# Altium schematic sheet DWM1000Breakout.SchDoc(DWM1000Breakout)
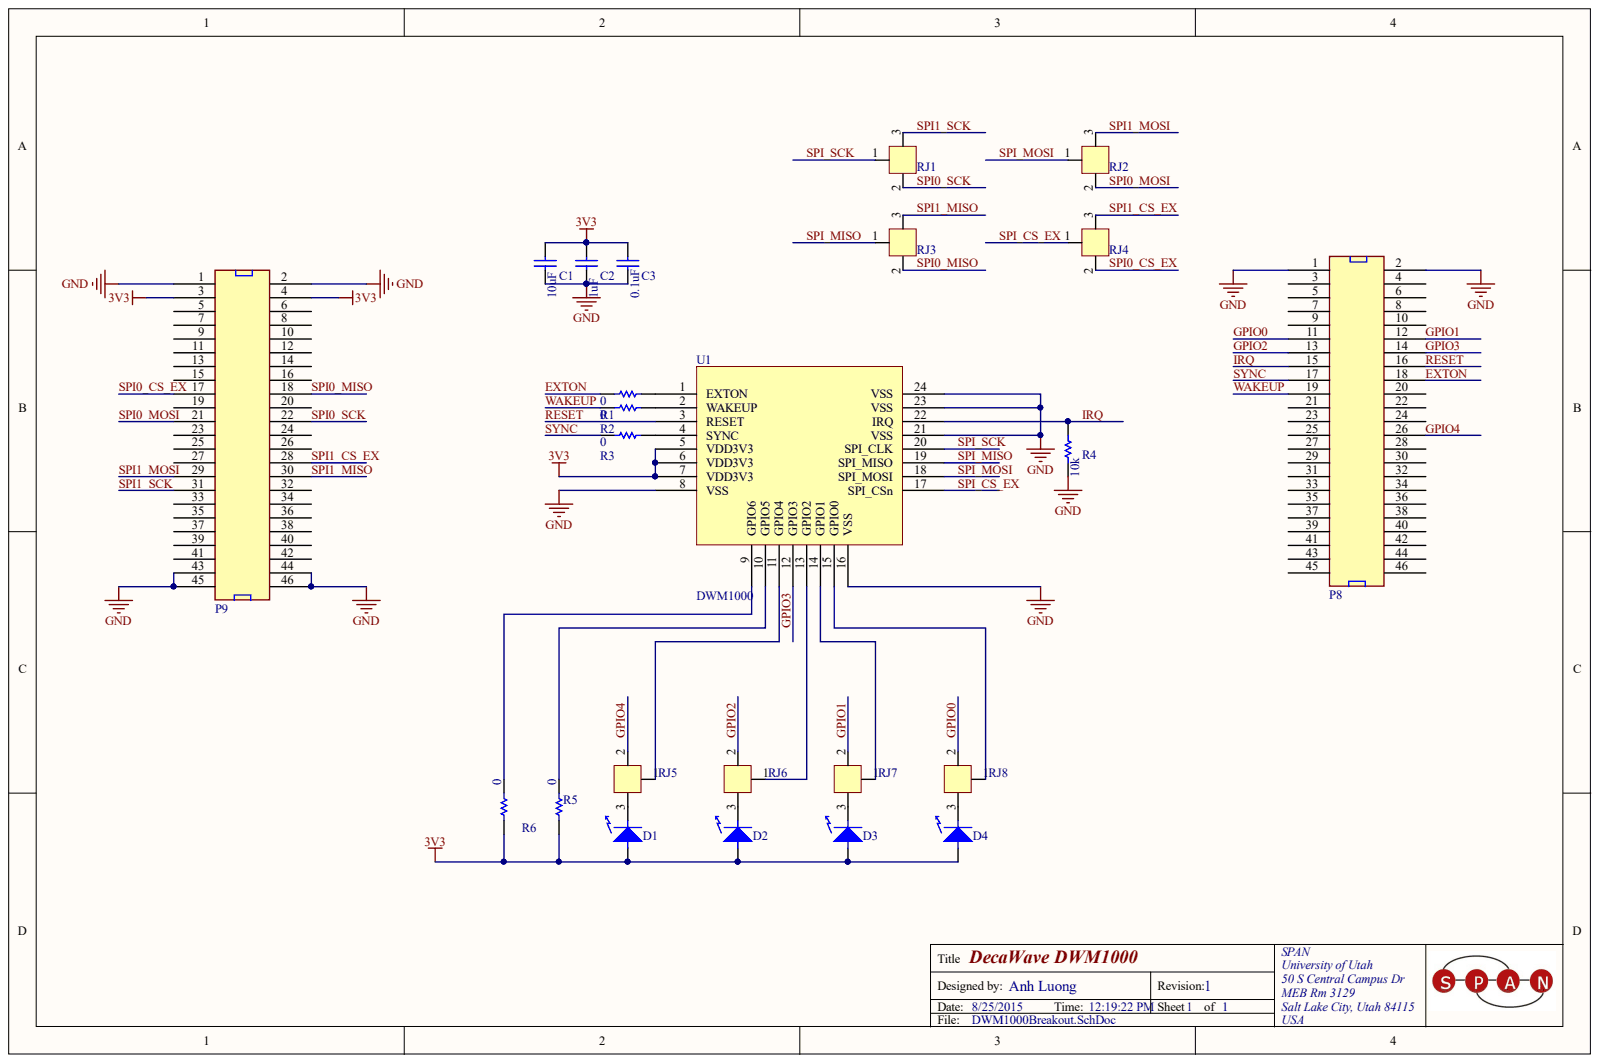
[diagram p0: DWM1000Breakout.SchDoc]

## Parts
C1  GRM188R60J106ME47D  [CAPC1608X08N (0603)]  (value not drawn)
C2  GRM188R61A105KA61D  [CAPC1608X08N (0603)]  (value not drawn)
C3  GRM188R71C104KA01D  [CAPC1608X08N (0603)]  (value not drawn)
D1  LG Q971-KN-1  [CHIPLED0603]  (value not drawn)
D2  LG Q971-KN-1  [CHIPLED0603]  (value not drawn)
D3  LG Q971-KN-1  [CHIPLED0603]  (value not drawn)
D4  LG Q971-KN-1  [CHIPLED0603]  (value not drawn)
P8  PREC023DAAN-RC  [HEADER23X2]  (value not drawn)
P9  PREC023DAAN-RC  [HEADER23X2]  (value not drawn)
R1  MCR03ERTJ000  [RESC1608X06N (0603)]  (value not drawn)
R2  MCR03ERTJ000  [RESC1608X06N (0603)]  (value not drawn)
R3  MCR03ERTJ000  [RESC1608X06N (0603)]  (value not drawn)
R4  Resistance (Ohms): 10k  [RESC1608X06N (0603)]  (hidden comment: MCR03ERTJ103)
R5  MCR03ERTJ000  [RESC1608X06N (0603)]  (value not drawn)
R6  MCR03ERTJ000  [RESC1608X06N (0603)]  (value not drawn)
RJ1  R_J  [RJ]
RJ2  R_J  [RJ]
RJ3  R_J  [RJ]
RJ4  R_J  [RJ]
RJ5  R_J  [RJ]
RJ6  R_J  [RJ]
RJ7  R_J  [RJ]
RJ8  R_J  [RJ]
U1  DWM1000  [DWM1000]

## Nets
3V3: C1.2 C2.2 C3.2 D1.1 D2.1 D3.1 D4.1 P9.3 P9.4 R5.2 R6.2 U1.5(VDD3V3) U1.6(VDD3V3) U1.7(VDD3V3)
GND: C1.1 C2.1 C3.1 P8.1 P8.2 P9.1 P9.2 P9.43 P9.44 P9.45 P9.46 R4.1 U1.8(VSS) U1.16(VSS) U1.21(VSS) U1.23(VSS) U1.24(VSS)
EXTON: P8.18 R1.1
GPIO0: P8.11 RJ8.2
GPIO1: P8.12 RJ7.2
GPIO2: P8.13 RJ6.2
GPIO3: P8.14 U1.12(GPIO3)
GPIO4: P8.26 RJ5.2
IRQ: P8.15 R4.2 U1.22(IRQ)
RESET: P8.16 U1.3(RESET)
SPI0_CS_EX: P9.17 RJ4.2
SPI0_MISO: P9.18 RJ3.2
SPI0_MOSI: P9.21 RJ2.2
SPI0_SCK: P9.22 RJ1.2
SPI1_CS_EX: P9.28 RJ4.3
SPI1_MISO: P9.30 RJ3.3
SPI1_MOSI: P9.29 RJ2.3
SPI1_SCK: P9.31 RJ1.3
SPI_CS_EX: RJ4.1 U1.17(SPI_CSn)
SPI_MISO: RJ3.1 U1.19(SPI_MISO)
SPI_MOSI: RJ2.1 U1.18(SPI_MOSI)
SPI_SCK: RJ1.1 U1.20(SPI_CLK)
SYNC: P8.17 R3.1
WAKEUP: P8.19 R2.1
NetD1_2: D1.2 RJ5.3
NetD2_2: D2.2 RJ6.3
NetD3_2: D3.2 RJ7.3
NetD4_2: D4.2 RJ8.3
NetR1_2: R1.2 U1.1(EXTON)
NetR2_2: R2.2 U1.2(WAKEUP)
NetR3_2: R3.2 U1.4(SYNC)
NetR5_1: R5.1 U1.10(GPIO5)
NetR6_1: R6.1 U1.9(GPIO6)
NetRJ5_1: RJ5.1 U1.11(GPIO4)
NetRJ6_1: RJ6.1 U1.13(GPIO2)
NetRJ7_1: RJ7.1 U1.14(GPIO1)
NetRJ8_1: RJ8.1 U1.15(GPIO0)

Not connected: P8 3 4 5 6 7 8 9 10 20 21 22 23 24 25 27 28 29 30 31 32 33 34 35 36 37 38 39 40 41 42 43 44 45 46; P9 5 6 7 8 9 10 11 12 13 14 15 16 19 20 23 24 25 26 27 32 33 34 35 36 37 38 39 40 41 42

## Library data of the parts
C1 = GRM188R60J106ME47D: CAP CER 10UF 6.3V 20% X5R 0603; Manufacturer: Murata Electronics North America; Capacitance: 10µF; Applications: General Purpose; Category: Capacitors; Component Kind: Standard; ComponentLink1Description: http://search.murata.co.jp/Ceramy/image/img/A01X/G101/ENG/GRM188R60J106ME47-01.pdf; ComponentLink1URL: http://search.murata.co.jp/Ceramy/image/img/A01X/G101/ENG/GRM188R60J106ME47-01.pdf; Features: -; Height - Seated (Max): -; Lead Spacing: -; Lead Style: -; Manufacturer Part Number: GRM188R60J106ME47D; Mounting Type: Surface Mount, MLCC; Operating Temperature: -55°C ~ 85°C; Package / Case: 0603 (1608 Metric); Packaging: Digi-Reel®; Pricing 1: 1=0.24, 10=0.2, 50=0.1126, 100=0.1, 250=0.075, 500=0.06626, 1000=0.05875 (USD); Ratings: -; RoHS: RoHS Compliant; Size / Dimension: 0.063" L x 0.031" W (1.60mm x 0.80mm); Stock 1: 2128324; Supplier 1: Digi-Key; Supplier 2: Digi-Key; Temperature Coefficient: X5R; Thickness (Max): 0.035" (0.90mm); Tolerance: ±20%; Voltage - Rated: 6.3V; Library Name: DWM1000Breakout.SchLib
C2 = GRM188R61A105KA61D: CAP CER 1UF 10V 10% X5R 0603; Manufacturer: Murata Electronics North America; Capacitance: 1.0µF; Applications: General Purpose; Category: Capacitors; Component Kind: Standard; ComponentLink1Description: http://search.murata.co.jp/Ceramy/image/img/A01X/G101/ENG/GRM188R61A105KA61-01.pdf; ComponentLink1URL: http://search.murata.co.jp/Ceramy/image/img/A01X/G101/ENG/GRM188R61A105KA61-01.pdf; Features: -; Height - Seated (Max): -; Lead Spacing: -; Lead Style: -; Manufacturer Part Number: GRM188R61A105KA61D; Mounting Type: Surface Mount, MLCC; Operating Temperature: -55°C ~ 85°C; Package / Case: 0603 (1608 Metric); Packaging: Cut Tape (CT); Pricing 1: 1=0.1, 10=0.041, 50=0.0224, 100=0.019, 250=0.01552, 500=0.01328, 1000=0.01035 (USD); Ratings: -; RoHS: RoHS Compliant; Size / Dimension: 0.063" L x 0.031" W (1.60mm x 0.80mm); Stock 1: 244919; Supplier 1: Digi-Key; Temperature Coefficient: X5R; Thickness (Max): 0.035" (0.90mm); Tolerance: ±10%; Voltage - Rated: 10V; Library Name: DWM1000Breakout.SchLib
C3 = GRM188R71C104KA01D: CAP CER 0.1UF 16V 10% X7R 0603; Manufacturer: Murata Electronics North America; Capacitance: 0.1µF; Applications: General Purpose; Category: Capacitors; Component Kind: Standard; ComponentLink1Description: http://search.murata.co.jp/Ceramy/image/img/A01X/G101/ENG/GRM188R71C104KA01-01.pdf; ComponentLink1URL: http://search.murata.co.jp/Ceramy/image/img/A01X/G101/ENG/GRM188R71C104KA01-01.pdf; Features: -; Height - Seated (Max): -; Lead Spacing: -; Lead Style: -; Manufacturer Part Number: GRM188R71C104KA01D; Mounting Type: Surface Mount, MLCC; Operating Temperature: -55°C ~ 125°C; Package / Case: 0603 (1608 Metric); Packaging: Cut Tape (CT); Pricing 1: 1=0.1, 10=0.019, 50=0.0102, 100=0.0086, 250=0.00704, 500=0.006, 1000=0.00468 (USD); Ratings: -; RoHS: RoHS Compliant; Size / Dimension: 0.063" L x 0.031" W (1.60mm x 0.80mm); Stock 1: 1458181; Supplier 1: Digi-Key; Temperature Coefficient: X7R; Thickness (Max): 0.035" (0.90mm); Tolerance: ±10%; Voltage - Rated: 16V; Library Name: DWM1000Breakout.SchLib
D1-D4 = LG Q971-KN-1: LED CHIPLED 570NM GREEN 0603 SMD; Manufacturer: OSRAM Opto Semiconductors Inc; Current - Test: 20mA; Lens Style/Size: Rectangle with Flat Top, 1mm x 0.8mm; Lens Type: Diffused; Millicandela Rating: 10mcd; Viewing Angle: 160°; Voltage - Forward (Vf) Typ: 2.2V; Wavelength - Peak: 572nm; Category: Optoelectronics; Color: Green; Component Kind: Standard; ComponentLink1Description: http://catalog.osram-os.com/catalogue/catalogue.do;jsessionid=DE4D071D0D2758CC117C711BF848053E?act=downloadFile&favOid=0200000600005069000100b6; ComponentLink1URL: http://catalog.osram-os.com/catalogue/catalogue.do;jsessionid=DE4D071D0D2758CC117C711BF848053E?act=downloadFile&favOid=0200000600005069000100b6; Height: 0.80mm; Luminous Flux @ Current - Test: -; Manufacturer Part Number: LG Q971-KN-1; Mounting Type: Surface Mount; Package / Case: 0603 (1608 Metric); Packaging: Tape & Reel (TR); Pricing 1: 4000=0.03632 (USD); RoHS: RoHS Compliant; Size / Dimension: 1.60mm L x 0.80mm W; Stock 1: 44000; Supplier 1: Digi-Key; Wavelength - Dominant: 570nm; Library Name: DWM1000Breakout.SchLib
P8 P9 = PREC023DAAN-RC: CONN HEADER .100" DUAL STR 46POS; Manufacturer: Sullins Connector Solutions; Connector Type: Header, Unshrouded; Number of Positions: 46; Number of Positions Loaded: All; Pitch: 0.100" (2.54mm); Category: Connectors, Interconnects; Color: Black; Component Kind: Standard; ComponentLink1Description: http://media.digikey.com/PDF/Data%20Sheets/Sullins%20PDFs/xRxCzzzDxxN-RC_11636-B.pdf; ComponentLink1URL: http://media.digikey.com/PDF/Data%20Sheets/Sullins%20PDFs/xRxCzzzDxxN-RC_11636-B.pdf; Contact Finish: Tin; Contact Finish Thickness: -; Contact Mating Length: 0.230" (5.84mm); Contact Type: Male Pin; Fastening Type: -; Features: -; Manufacturer Part Number: PREC023DAAN-RC; Mounting Type: Through Hole; Number of Rows: 2; Packaging: Bulk; Pricing 1: 1=0.79, 10=0.706, 100=0.5813, 500=0.45952, 1000=0.39447, 5000=0.35987, 10000=0.34603 (USD); RoHS: RoHS Compliant; Row Spacing: 0.100" (2.54mm); Stock 1: 0; Supplier 1: Digi-Key; Termination: Solder; Library Name: Chronos.SchLib
R1-R3 R5 R6 = MCR03ERTJ000: RES 0.0 OHM 1/10W JUMP 0603 SMD; Manufacturer: Rohm Semiconductor; Power (Watts): 0.1W, 1/10W; Resistance (Ohms): 0.0; Tolerance: Jumper; Category: Resistors; Component Kind: Standard; ComponentLink1Description: http://rohmfs.rohm.com/en/products/databook/datasheet/passive/resistor/chip_resistor/mcr_g.pdf; ComponentLink1URL: http://rohmfs.rohm.com/en/products/databook/datasheet/passive/resistor/chip_resistor/mcr_g.pdf; Composition: Thick Film; Features: -; Height: 0.022" (0.55mm); Manufacturer Part Number: MCR03ERTJ000; Number of Terminations: 2; Package / Case: 0603 (1608 Metric); Packaging: Cut Tape (CT); Pricing 1: 1=0.1, 25=0.0064, 100=0.0035, 250=0.00268, 500=0.00214, 1000=0.00157 (USD); RoHS: RoHS Compliant; Size / Dimension: 0.063" L x 0.031" W (1.60mm x 0.80mm); Stock 1: 127296; Supplier 1: Digi-Key; Supplier Device Package: 0603 (1608 Metric); Temperature Coefficient: -; Library Name: DWM1000Breakout.SchLib
R4 = MCR03ERTJ103: RES 10K OHM 1/10W 5% 0603 SMD; Manufacturer: Rohm Semiconductor; Power (Watts): 0.1W, 1/10W; Temperature Coefficient: ±200ppm/°C; Category: Resistors; Component Kind: Standard; ComponentLink1Description: http://rohmfs.rohm.com/en/products/databook/datasheet/passive/resistor/chip_resistor/mcr_g.pdf; ComponentLink1URL: http://rohmfs.rohm.com/en/products/databook/datasheet/passive/resistor/chip_resistor/mcr_g.pdf; Composition: Thick Film; Features: -; Height: 0.022" (0.55mm); Manufacturer Part Number: MCR03ERTJ103; Number of Terminations: 2; Package / Case: 0603 (1608 Metric); Packaging: Tape & Reel (TR); Pricing 1: 5000=0.00103, 10000=0.00089, 25000=0.00078, 50000=0.00072, 125000=0.0007 (USD); RoHS: RoHS Compliant; Size / Dimension: 0.063" L x 0.031" W (1.60mm x 0.80mm); Stock 1: 330000; Supplier 1: Digi-Key; Supplier Device Package: 0603 (1608 Metric); Tolerance: ±5%; Library Name: DWM1000Breakout.SchLib
RJ1-RJ8 = R_J: Resistor Jumper; Value: DNP; Applications: General Purpose; Component Kind: Standard; Mounting Type: Surface Mount, MLCC; Package / Case: 0603 (1608 Metric); Library Name: DWM1000Breakout.SchLib
U1 = DWM1000: MOD IEEE802.15.4-2011 UWB TXRX; Manufacturer: Decawave Limited; Antenna Type: Integrated, Chip; Current - Receiving: 64mA; Current - Transmitting: 31mA; Data Rate: 6.8Mbps; Frequency: 3.5GHz ~ 6.5GHz; Serial Interfaces: SPI; Voltage - Supply: 2.8 V ~ 3.6 V; Category: RF/IF and RFID; Component Kind: Standard; ComponentLink1Description: http://www.decawave.com/sites/default/files/product-pdf/dwm1000-product-brief.pdf; ComponentLink1URL: http://www.decawave.com/sites/default/files/product-pdf/dwm1000-product-brief.pdf; Library Name: DWM1000Breakout.SchLib; Manufacturer Part Number: DWM1000; Memory Size: -; Modulation: -; Mounting Type: Surface Mount; Operating Temperature: -40°C ~ 85°C; Package / Case: Module; Packaging: Cut Tape (CT); Power - Output: -; Pricing 1: 1=32.16, 10=26.704, 100=24.24, 250=22.51296 (USD); Protocol: -; RF Family/Standard: 802.15.4; RoHS: RoHS Compliant; Sensitivity: -; Stock 1: 1028; Supplier 1: Digi-Key; Supplier Part Number 1: 1479-1002-1-ND
